# Altium schematic sheet RF_B2B_WiFi_WROOM02_V1.01.SchDoc(RF_B2B_WiFi_WROOM02_V1.01)
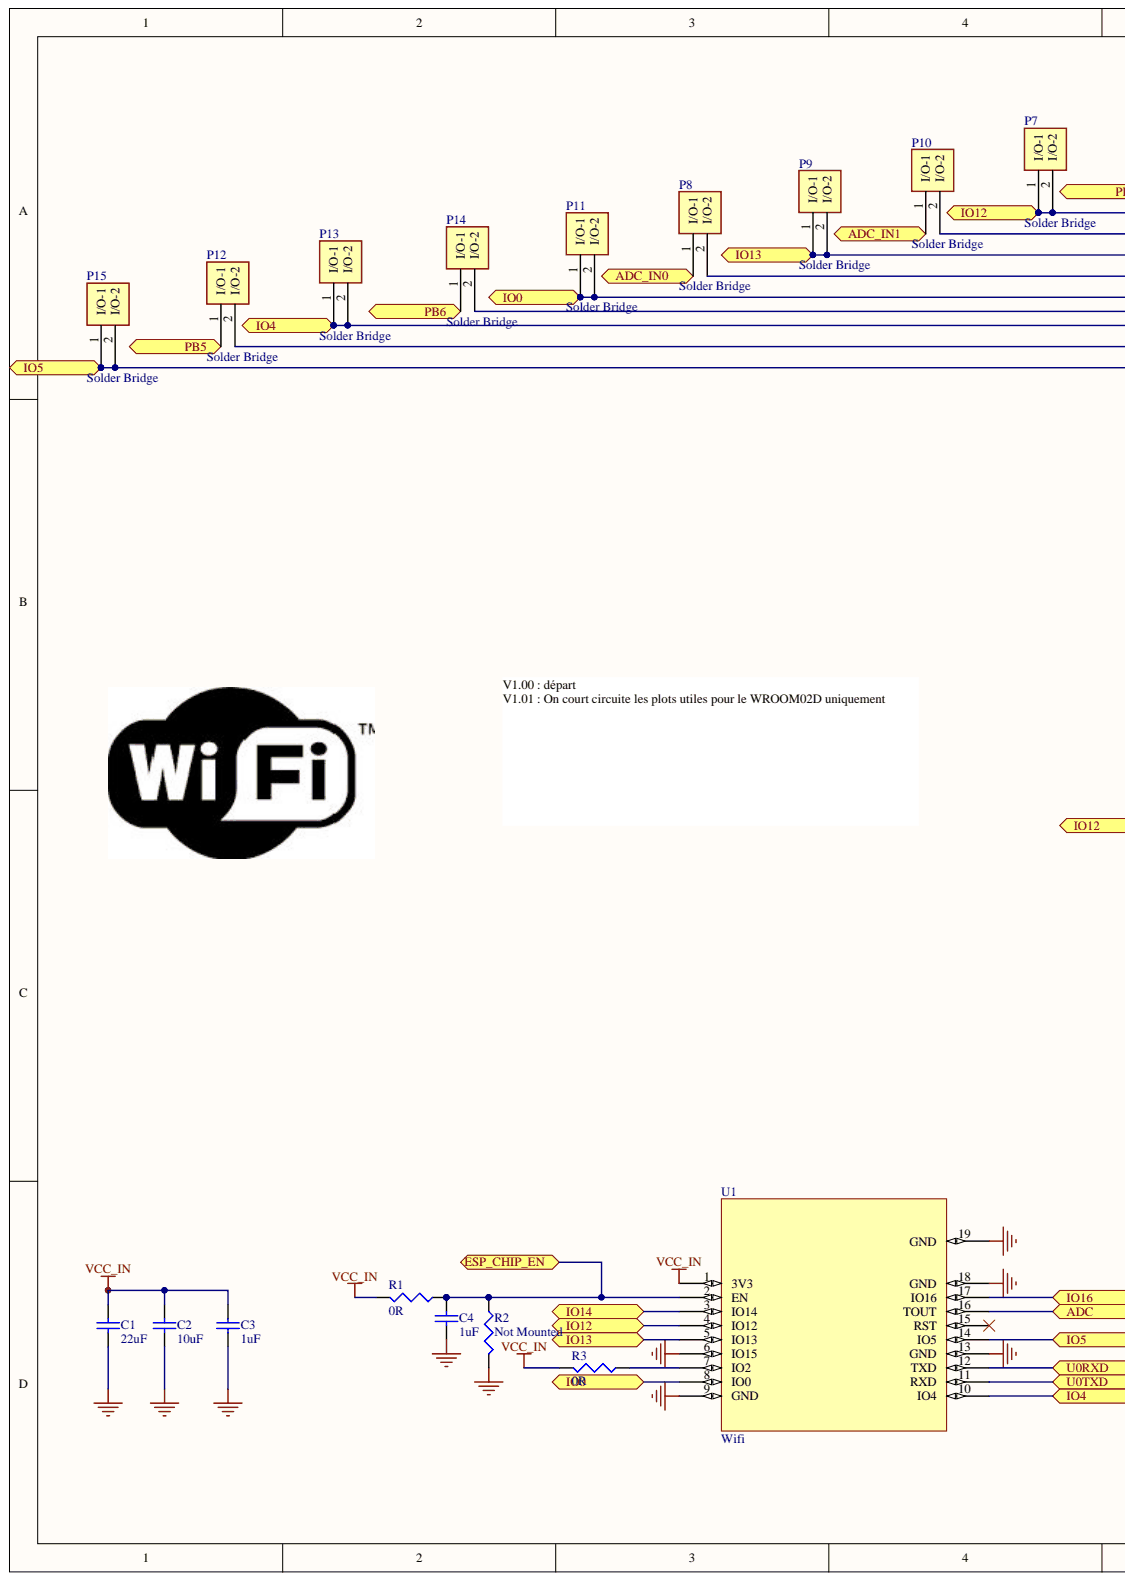
[diagram p0: RF_B2B_WiFi_WROOM02_V1.01.SchDoc, left]
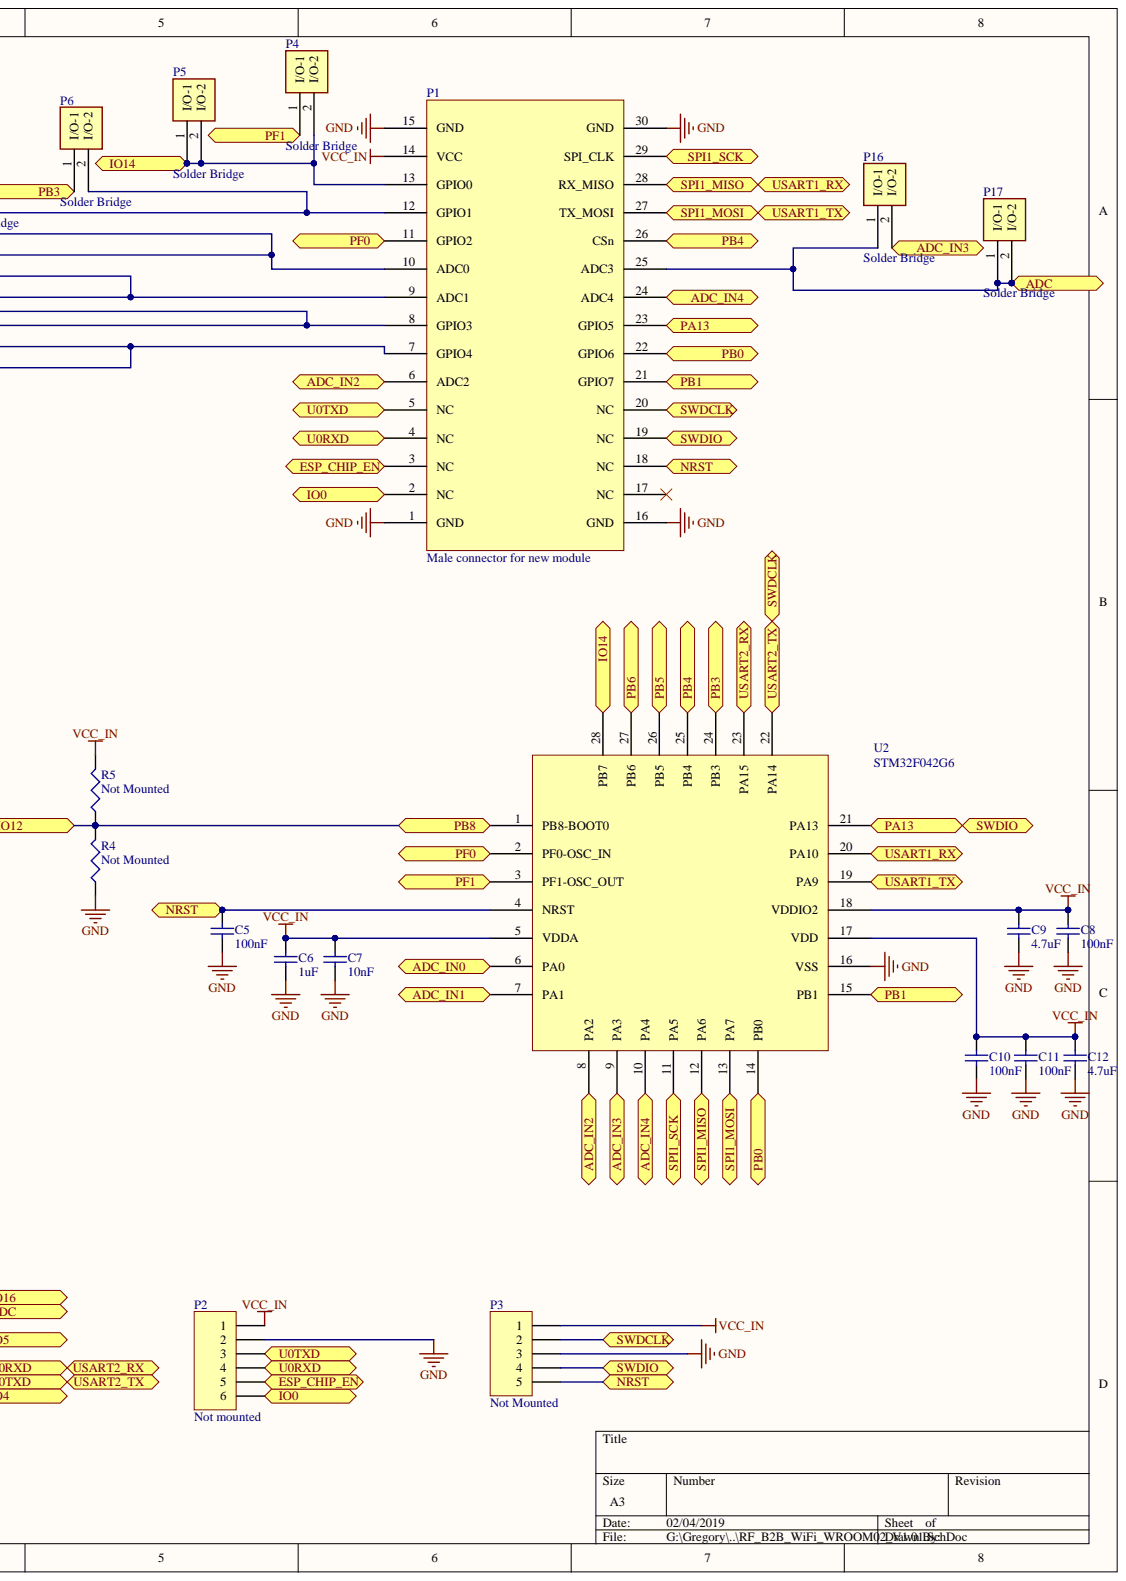
[diagram p1: RF_B2B_WiFi_WROOM02_V1.01.SchDoc, right]

## Parts
C1  22uF  [0603-3D - CAP]
C2  10uF  [0402-3D - CAP]
C3  1uF  [0402-3D - CAP]
C4  1uF  [0603-3D - CAP]
C5  100nF  [0402-3D - CAP]  (no BOM)
C6  1uF  [0402-3D - CAP]  (no BOM)
C7  10nF  [0402-3D - CAP]  (no BOM)
C8  100nF  [0402-3D - CAP]  (no BOM)
C9  4.7uF  [0402-3D - CAP]  (no BOM)
C10  100nF  [0402-3D - CAP]  (no BOM)
C11  100nF  [0402-3D - CAP]  (no BOM)
C12  4.7uF  [0402-3D - CAP]  (no BOM)
P1  Male connector for new module  Comment2: Male  [CON30 - BTBM050030G0WH35]
P2  Not mounted  [MHDR1X6 - PROG @mod]  (no BOM)
P3  Not Mounted  [MHDR1X5 - PROG @mod]  (no BOM)
P4  Solder Bridge  [Solder Bridge]  (no BOM)
P5  Solder Bridge  [Solder Bridge]  (no BOM)
P6  Solder Bridge  [Solder Bridge]  (no BOM)
P7  Solder Bridge  [Solder Bridge]  (no BOM)
P8  Solder Bridge  [Solder Bridge]  (no BOM)
P9  Solder Bridge  [Solder Bridge]  (no BOM)
P10  Solder Bridge  [Solder Bridge]  (no BOM)
P11  Solder Bridge  [Solder Bridge]  (no BOM)
P12  Solder Bridge  [Solder Bridge]  (no BOM)
P13  Solder Bridge  [Solder Bridge]  (no BOM)
P14  Solder Bridge  [Solder Bridge]  (no BOM)
P15  Solder Bridge  [Solder Bridge]  (no BOM)
P16  Solder Bridge  [Solder Bridge]  (no BOM)
P17  Solder Bridge  [Solder Bridge]  (no BOM)
R1  0R  [0402-3D - RES]
R2  Not Mounted  [0402-3D - RES]  (no BOM)
R3  0R  [0402-3D - RES]
R4  Not Mounted  [0402-3D - RES]  (no BOM)
R5  Not Mounted  [0402-3D - RES]  (no BOM)
U1  Wifi  [Module WiFi Espressif - ESP-WROOM-02D]
U2  STM32F042G6  [UFQFPN28 - STM32F042G6]  (no BOM)

## Ports
ADC, ADC_IN0, ADC_IN1, ADC_IN2, ADC_IN3, ADC_IN4, ESP_CHIP_EN, IO0, IO4, IO5, IO12, IO13, IO14, IO16, NRST, PA13, PB0, PB1, PB3, PB4, PB5, PB6, PB8, PF0, PF1, SPI1_MISO, SPI1_MOSI, SPI1_SCK, SWDCLK, SWDIO, U0RXD, U0TXD, USART1_RX, USART1_TX, USART2_RX, USART2_TX

## Nets
GND: C1.2 C2.1 C3.1 C4.1 C5.1 C6.1 C7.1 C8.1 C9.1 C10.1 C11.1 C12.1 P1.1(GND) P1.15(GND) P1.16(GND) P1.30(GND) P2.2 P3.3 R2.2 R4.1 U1.6(IO15) U1.9(GND) U1.13(GND) U1.18(GND) U1.19(GND) U2.16(VSS)
VCC_IN: C1.1 C2.2 C3.2 C6.2 C7.2 C8.2 C9.2 C10.2 C11.2 C12.2 P1.14(VCC) P2.1 P3.1 R1.2 R3.2 R5.2 U1.1(3V3) U2.5(VDDA) U2.17(VDD) U2.18(VDDIO2)
ADC: P1.25(ADC3) P16.1 P17.1 P17.2 U1.16(TOUT) ; port ADC
ADC_IN0: P8.1 U2.6(PA0) ; port ADC_IN0
ADC_IN1: P10.1 U2.7(PA1) ; port ADC_IN1
ADC_IN2: P1.6(ADC2) U2.8(PA2) ; port ADC_IN2
ADC_IN3: P16.2 U2.9(PA3) ; port ADC_IN3
ADC_IN4: P1.24(ADC4) U2.10(PA4) ; port ADC_IN4
ESP_CHIP_EN: C4.2 P1.3(NC) P2.5 R1.1 R2.1 U1.2(EN) ; port ESP_CHIP_EN
IO0: P1.2(NC) P1.9(ADC1) P2.6 P8.2 P11.1 P11.2 U1.8(IO0) ; port IO0
IO4: P1.8(GPIO3) P13.1 P13.2 P14.2 U1.10(IO4) ; port IO4
IO5: P1.7(GPIO4) P12.2 P15.1 P15.2 U1.14(IO5) ; port IO5
IO12: P1.12(GPIO1) P6.2 P7.1 P7.2 R4.2 R5.1 U1.4(IO12) U2.1(PB8-BOOT0) ; port IO12, port PB8
IO13: P1.10(ADC0) P9.1 P9.2 P10.2 U1.5(IO13) ; port IO13
IO14: P1.13(GPIO0) P4.2 P5.1 P5.2 U1.3(IO14) U2.28(PB7) ; port IO14
IO16: U1.17(IO16) ; port IO16
NRST: C5.2 P1.18(NC) P3.5 U2.4(NRST) ; port NRST
PA13: P1.19(NC) P1.23(GPIO5) P3.4 U2.21(PA13) ; port PA13, port SWDIO
PB0: P1.22(GPIO6) U2.14(PB0) ; port PB0
PB1: P1.21(GPIO7) U2.15(PB1) ; port PB1
PB3: P6.1 U2.24(PB3) ; port PB3
PB4: P1.26(CSn) U2.25(PB4) ; port PB4
PB5: P12.1 U2.26(PB5) ; port PB5
PB6: P14.1 U2.27(PB6) ; port PB6
PF0: P1.11(GPIO2) U2.2(PF0-OSC_IN) ; port PF0
PF1: P4.1 U2.3(PF1-OSC_OUT) ; port PF1
SPI1_MISO: P1.28(RX_MISO) U2.12(PA6) U2.20(PA10) ; port SPI1_MISO, port USART1_RX
SPI1_MOSI: P1.27(TX_MOSI) U2.13(PA7) U2.19(PA9) ; port SPI1_MOSI, port USART1_TX
SPI1_SCK: P1.29(SPI_CLK) U2.11(PA5) ; port SPI1_SCK
SWDCLK: P1.5(NC) P1.20(NC) P2.3 P3.2 U1.11(RXD) U2.22(PA14) ; port SWDCLK, port U0TXD, port USART2_TX
U0RXD: P1.4(NC) P2.4 U1.12(TXD) U2.23(PA15) ; port U0RXD, port USART2_RX
NetR3_1: R3.1 U1.7(IO2)

Not connected: P1 17(NC); U1 15(RST)

## Library data of the parts
C1 = 57: MLCC X5R 0603 10V 20%; Manufacturer1: Murata; Database Table Name: Capacitors; Reference1: GRM188R61A226ME15D; SEICER_ARTICLE: 60002458; Category: Capacitor; Comment2: 10V; Component Kind: Standard; DateCreated: 04/12/2017; ID: 57; Library Name: Database_components.DbLib; Signal Integrity: Cap; Simulation: CAP; Termination: S; Type: MLCC; Creator: Benoit
C2 = 16: MLCC X5R 0402 6.3V 20%; Manufacturer1: Murata; Database Table Name: Capacitors; Reference1: GRM155R60J106ME44D; Reference2: CC0402MRX5R5BB106; SEICER_ARTICLE: 60002238; Category: Capacitor; Comment2: 6.3V; Component Kind: Standard; DateCreated: 04/12/2017; ID: 16; Library Name: Database_components.DbLib; Manufacturer2: Yageo; Signal Integrity: Cap; Simulation: CAP; Termination: S; Type: MLCC; Creator: Benoit
C3 C6 = 33: MLCC X5R 0402 10V 10%; Manufacturer1: AVX; Database Table Name: Capacitors; Reference1: 0402ZD105KAT2A; Reference2: CS1005X5R105K100NR; SEICER_ARTICLE: 60002068; Category: Capacitor; Comment2: 10V; DateCreated: 04/12/2017; ID: 33; Library Name: Database_components.DbLib; Manufacturer2: Samwha; Signal Integrity: Cap; Simulation: CAP; Termination: S; Type: MLCC; Creator: Benoit
  C3: Component Kind: Standard
C4 = 36: MLCC X7R 0603 16V 10%; Manufacturer1: Yageo; Database Table Name: Capacitors; Reference1: CC0603KRX7R7BB105; Reference2: GRM188R71C105KA12D; Category: Capacitor; Comment2: 16V; Component Kind: Standard; DateCreated: 04/12/2017; ID: 36; Library Name: Database_components.DbLib; Manufacturer2: Murata; Signal Integrity: Cap; Simulation: CAP; Termination: S; Type: MLCC; Creator: Benoit
C5 C8 C10 C11 = 4: MLCC X7R 0402 16V 10%; Manufacturer1: Murata; Database Table Name: Capacitors; Reference1: GRM155R71C104KA88D; Reference2: GRM155R61A104KA01D; Reference3: C1005X7R1H104K050BB; SEICER_ARTICLE: 60001041; Category: Capacitor; Comment2: 16V; DateCreated: 04/12/2017; ID: 4; Library Name: Database_components.DbLib; Manufacturer2: Murata; Manufacturer3: TDK; Signal Integrity: Cap; Simulation: CAP; Termination: S; Type: MLCC; Creator: Benoit
C7 = 13: MLCC X7R 0402 50V 10%; Manufacturer1: Samsung; Database Table Name: Capacitors; Reference1: CL05B103KB5NNNC; Reference2: GRM155R71H103KA88; Reference3: 885012205067; SEICER_ARTICLE: 60002274; Category: Capacitor; Comment2: 50V; DateCreated: 04/12/2017; ID: 13; Library Name: Database_components.DbLib; Manufacturer2: Murata; Manufacturer3: Wurth; Signal Integrity: Cap; Simulation: CAP; Termination: S; Type: MLCC; Creator: Benoit
C9 C12 = 71: MLCC X5R 0402 10V 20%; Manufacturer1: TDK; Comment_lib: à éviter; Database Table Name: Capacitors; Reference1: C1005X5R1A475M050BC; SEICER_ARTICLE: 6000S027; Category: Capacitor; Comment2: 10V; DateCreated: 04/12/2017; ID: 71; Library Name: Database_components.DbLib; Signal Integrity: Cap; Simulation: CAP; Termination: S; Type: MLCC; Creator: Benoit
P1 = 152: Manufacturer1: Ningbo; Database Table Name: Connectors; Reference1: BTBM050030G0WH35; SEICER_ARTICLE: FC2218-23002033; Category: Connector; Component Kind: Standard; Datasheet1: 2000P.pdf; DateCreated: 04/12/2017; Description: Male connector for new module; ID: 152; Library Name: Database_components.DbLib; Termination: S; Type: Plug; Creator: Benoit
P2 = 535: 6 pins 1.27mm (for debug); Database Table Name: Connectors; Category: Connector; DateCreated: 07/03/2018; ID: 535; Library Name: Database_components.DbLib; Signal Integrity: Connector; Termination: T; Type: Header; Creator: Grégory
P3 = 485: 5 pins 1.27mm (for @mod debug); Database Table Name: Connectors; Category: Connector; DateCreated: 22/01/2018; ID: 485; Library Name: Database_components.DbLib; Signal Integrity: Connector; Termination: S; Type: Header; Creator: Jérémy
P4-P17 = CON2PINS: Not mounted; Library Name: Commun_sch.SCHLIB
R1-R5 = 215: 0402 1%; Manufacturer1: Yageo; Database Table Name: Resistors; Reference1: RC0402FR-070RL; Reference2: CRCW04020000Z0EDHP; SEICER_ARTICLE: 80001618; Category: Resistor; Comment2: 1%; DateCreated: 04/12/2017; ID: 215; Library Name: Database_components.DbLib; Manufacturer2: Vishay; Signal Integrity: Res; Simulation: RESISTOR; Termination: S; Type: Resistor; Creator: Benoit
  R1 R3: Component Kind: Standard
U1 = 1015: wifi module; Comment2: ESP-WROOM-02D; Database Table Name: Modules; Component Kind: Standard; DateCreated: 29/01/2019; ID: 1015; Library Name: Database_components.DbLib; Termination: S; Type: Module; Creator: 4
U2 = 868: ARM Cortex M0 processor; Database Table Name: MCU_Mem_RFIC; Category: MCU_Mem_RFIC; DateCreated: 09/01/2019; ID: 868; Library Name: Database_components.DbLib; Termination: S; Type: MCU; Creator: Grégory
